# Altium schematic sheet Main.SchDoc
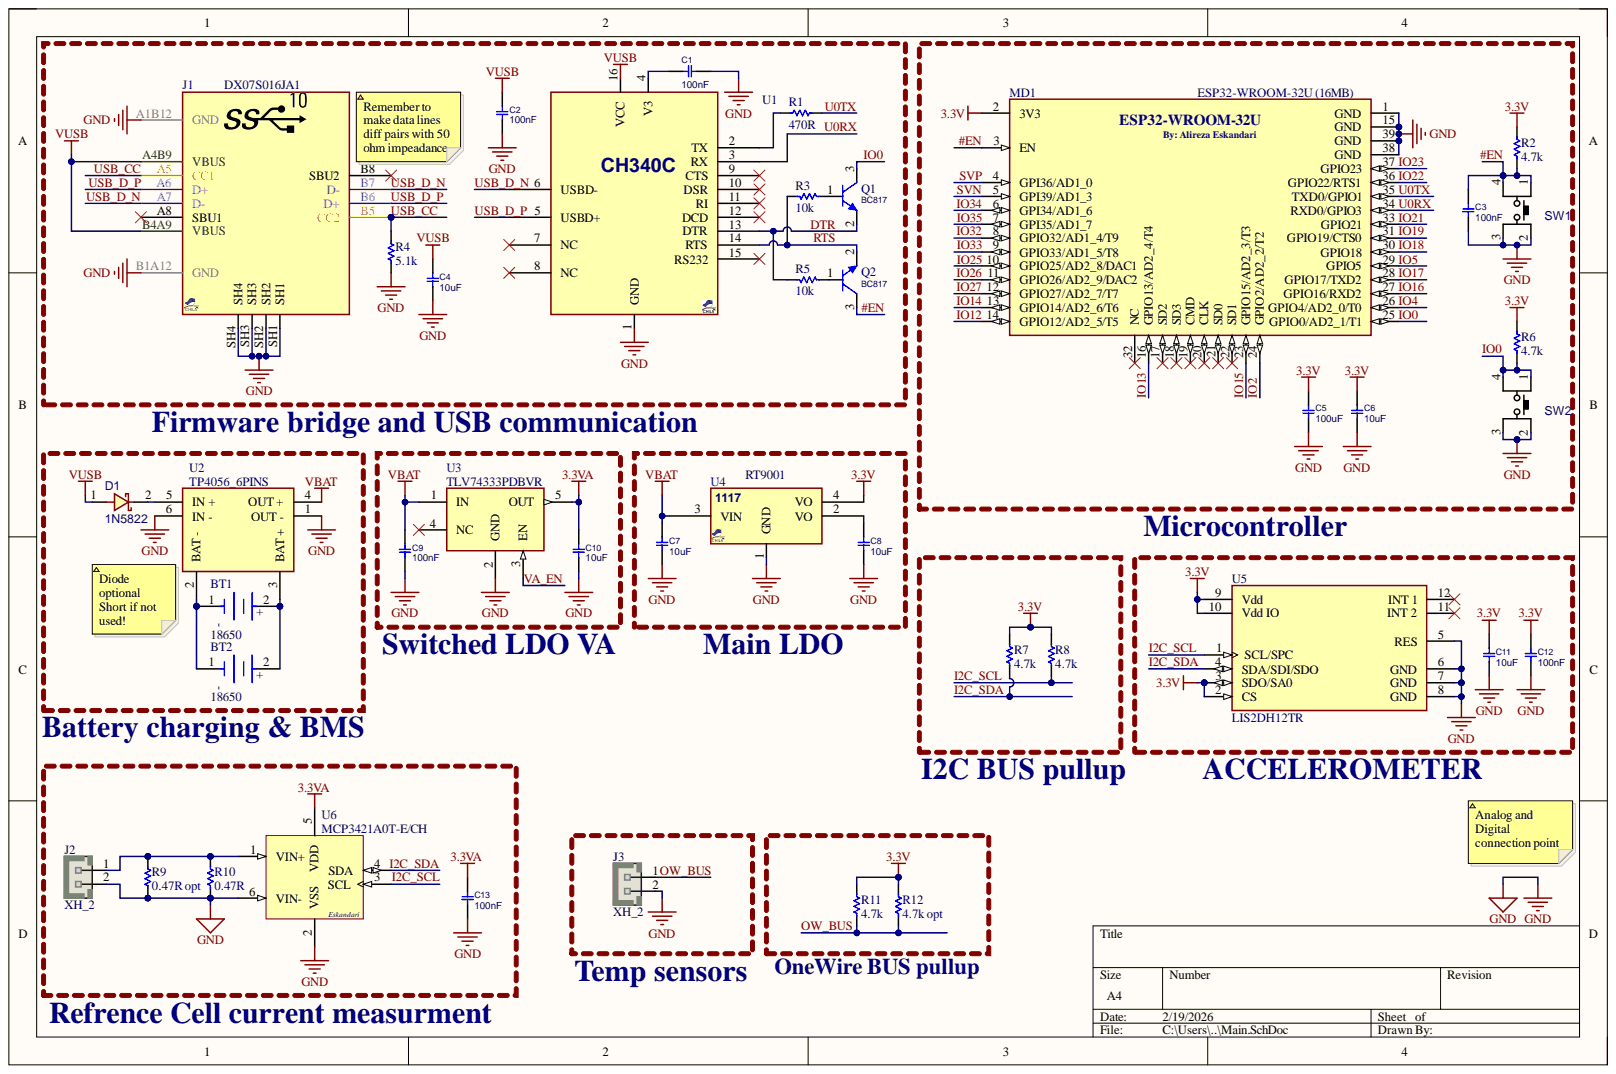
[diagram p0: Main.SchDoc]

## Parts
BT1  18650  [FP-1042P-MFG]
BT2  18650  [FP-1042P-MFG]
C1  100nF  [C0805]
C2  100nF  [C0805]
C3  100nF  [C0805]
C4  10uF  [C0805]
C5  100uF  [C0805]
C6  10uF  [C0805]
C7  10uF  [C0805]
C8  10uF  [C0805]
C9  100nF  [C0805]
C10  10uF  [C0805]
C11  10uF  [C0805]
C12  100nF  [C0805]
C13  100nF  [C0805]
D1  1N5822  [D_SMA]
J1  DX07S016JA1  [USBC_SMD_16_DX07S016JA1]
J2  XH_2  [XH_2]
J3  XH_2  [XH_2]
MD1  ESP32-WROOM-32U (16MB)  [FP-ESP32-WROOM-32U_16MB-MFG]
Q1  BC817  [SOT23_3]
Q2  BC817  [SOT23_3]
R1  470R  [RES0805]
R2  4.7k  [RES0805]
R3  10k  [RES0805]
R4  5.1k  [RES0805]
R5  10k  [RES0805]
R6  4.7k  [RES0805]
R7  4.7k  [RES0805]
R8  4.7k  [RES0805]
R9  0.47R opt  [RES0805]
R10  0.47R  [RES0805]
R11  4.7k  [RES0805]
R12  4.7k opt  [RES0805]
SW1  PUSHBUTTON  [SWPBS_SPDT_5X5X1]  (value not drawn)
SW2  PUSHBUTTON  [SWPBS_SPDT_5X5X1]  (value not drawn)
U1  CH340C  [SO_16]
U2  TP4056_6PINS  [TP4056_6PINs]
U3  TLV74333PDBVR  [FP-DBV0005A-MFG]
U4  RT9001  PARAM2: 3.3V  [SOT223_4]
U5  LIS2DH12TR  [FP-LGA-12-8365767-MFG]
U6  MCP3421A0T-E/CH  [SOT-23-CH6_L]

## Nets
3.3V: C5.2 C6.2 C11.2 C12.1 MD1.2(3V3) R2.2 R6.2 R7.2 R8.2 R11.2 R12.2 U4.4(VO) U5.2(CS) U5.3(SDO/SA0) U5.9(Vdd) U5.10(Vdd_IO)
3.3VA: C10.2 C13.2 U3.5(OUT) U6.5(VDD)
GND: C1.1 C2.1 C3.1 C4.1 C5.1 C6.1 C7.1 C8.1 C9.1 C10.1 C11.1 C12.2 C13.1 J1.A1B12(GND) J1.B1A12(GND) J1.SH1 J1.SH2 J1.SH3 J1.SH4 J2.2 J3.2 MD1.1(GND) MD1.15(GND) MD1.38(GND) MD1.39(GND) R4.1 R9.1 R10.1 SW1.2 SW1.3 SW2.2 SW2.3 U1.1(GND) U2.1(OUT_-) U2.6(IN_-) U3.2(GND) U4.1(GND) U5.5(RES) U5.6(GND) U5.7(GND) U5.8(GND) U6.2(VSS) U6.6(VIN-)
VBAT: C7.2 C9.2 U2.4(OUT_+) U3.1(IN) U4.3(VIN)
VUSB: C2.2 C4.2 D1.1 J1.A4B9(VBUS) J1.B4A9(VBUS) U1.16(VCC)
#EN: C3.2 MD1.3(EN) Q2.3 R2.1 SW1.1 SW1.4
DTR: Q1.2 R5.2 U1.13(DTR)
I2C_SCL: R8.1 U5.1(SCL/SPC) U6.3(SCL)
I2C_SDA: R7.1 U5.4(SDA/SDI/SDO) U6.4(SDA)
IO0: MD1.25(GPIO0/AD2_1/T1) Q1.3 R6.1 SW2.1 SW2.4
IO2: MD1.24(GPIO2/AD2_2/T2)
IO4: MD1.26(GPIO4/AD2_0/T0)
IO5: MD1.29(GPIO5)
IO12: MD1.14(GPIO12/AD2_5/T5)
IO13: MD1.16(GPIO13/AD2_4/T4)
IO14: MD1.13(GPIO14/AD2_6/T6)
IO15: MD1.23(GPIO15/AD2_3/T3)
IO16: MD1.27(GPIO16/RXD2)
IO17: MD1.28(GPIO17/TXD2)
IO18: MD1.30(GPIO18)
IO19: MD1.31(GPIO19/CTS0)
IO21: MD1.33(GPIO21)
IO22: MD1.36(GPIO22/RTS1)
IO23: MD1.37(GPIO23)
IO25: MD1.10(GPIO25/AD2_8/DAC1)
IO26: MD1.11(GPIO26/AD2_9/DAC2)
IO27: MD1.12(GPIO27/AD2_7/T7)
IO32: MD1.8(GPIO32/AD1_4/T9)
IO33: MD1.9(GPIO33/AD1_5/T8)
IO34: MD1.6(GPI34/AD1_6)
IO35: MD1.7(GPI35/AD1_7)
OW_BUS: J3.1 R11.1 R12.1
RTS: Q2.2 R3.2 U1.14(RTS)
SVN: MD1.5(GPI39/AD1_3)
SVP: MD1.4(GPI36/AD1_0)
U0RX: MD1.34(RXD0/GPIO3) U1.3(RX)
U0TX: MD1.35(TXD0/GPIO1) R1.1
USB_CC: J1.A5(CC1) J1.B5(CC2) R4.2
USB_D_N: J1.A7(D-) J1.B7(D-) U1.6(USBD-)
USB_D_P: J1.A6(D+) J1.B6(D+) U1.5(USBD+)
VA_EN: U3.3(EN)
NetBT1_1: BT1.1 BT2.1 U2.2(BAT_-)
NetBT1_2: BT1.2 BT2.2 U2.3(BAT_+)
NetC1_2: C1.2 U1.4(V3)
NetC8_2: C8.2 U4.2(VO)
NetD1_2: D1.2 U2.5(IN_+)
NetJ2_1: J2.1 R9.2 R10.2 U6.1(VIN+)
NetQ1_1: Q1.1 R3.1
NetQ2_1: Q2.1 R5.1
NetR1_2: R1.2 U1.2(TX)

Not connected: J1 A8(SBU1) B8(SBU2); MD1 17(SD2) 18(SD3) 19(CMD) 20(CLK) 21(SD0) 22(SD1) 32(NC); U1 7(NC) 8(NC) 9(CTS) 10(DSR) 11(RI) 12(DCD) 15(RS232); U3 4(NC); U5 11(INT_2) 12(INT_1)

## Library data of the parts
BT1 BT2 = BATTERY-HOLDER-18650: BATTERY HOLDER 18650 SMD TAB; Number of Cells: 1; Component Kind: Standard; Lead Free: Lead Free; Max Operating Temperature: 145°C; Min Operating Temperature: -50°C; Mount: Surface Mount; Package Quantity: 200; Packaging: Tray; Termination: SMD/SMT; Library Name: alib.IntLib
C1-C13 = CAP_SMD_MLCC_0805: CAP, MLCC; MANF_P#: MANF_PART#; PARAM: -, -%; PARAM2: -V; ASSEMBLY: ASSEMBLY; Component Kind: Standard; Library Name: passive_smd.SchLib; MANF: -; PRICE: 100= 1$
  C1-C3 C9 C12 C13: VALUE: 100nF
  C4 C6-C8 C10 C11: VALUE: 10uF
  C5: VALUE: 100uF
D1 = DIODE_SMD_SCHOTTKY_1N5822: DIODE, SCHOTTKY, 40V, 3A; DESC: DESCRIPTION; MANF: DIODES; ASSEMBLY: ASSEMBLY; Component Kind: Standard; Library Name: diode.SchLib; MANF_P#: 1N5822; PARAM: 40V; PARAM2: 3A; PRICE: PRICE; VALUE: 1N5822
J1 = CONN_USBC_SMD_16_DX07S016JA1: CONN, USB, Type C, USB 2.0, Right-Angle, SMD; MANF: JAE; MANF_P#: DX07S016JA1R1500; PARAM: 5A; Component Kind: Standard; Library Name: conn_usbc.SchLib; Price: 1.5$; Value: DX07S016JA1
J2 J3 = XH_2: PW10-x-M, JST-BxB-XH-A pitch: 2.5mm, 3A/250v; MANF: JST; MANF_P#: B2B-XH-A; PARAM: 3.0A; PARAM2: 250v; Component Kind: Standard; Library Name: conn_wire2board.SchLib; VALUE: XH_2
MD1 = ESP32-WROOM-32U: RX TXRX MODULE WIFI U.FL SMD; Data Rate: 150Mbps; Frequency: 2.5GHz; Interface: I2C; Memory Size: 536KB; Sensitivity: -98dBm; Case/Package: SMD/SMT; Component Kind: Standard; Max Operating Temperature: 85°C; Min Operating Temperature: -40°C; Mount: Surface Mount; Packaging: Tape and Reel; Library Name: alib.IntLib
Q1 Q2 = NPN_BC817_SOT23: BJT, NPN, 45v, 0.5A, SMD, SOT23; MANF: NXP, ON; PARAM: 0.5A, Hfe100~600; PARAM2: 45v, 250mW; Component Kind: Standard; Library Name: transistor_bjt.SchLib; MANF_P#: BC817; Simulation: NS3904; VALUE: BC817
R1-R12 = RES SMD PACKAGE: TOTAL SMD RESISTOR PACK; Designer: Alireza Esknadari; ll: RES SMD PAKAGES; Component Kind: Standard; Library Name: alib.IntLib
SW1 SW2 = SWPBS_SPDT_5X5PTS: SWITCH, PUSH BUTTON, TACTILE, SMD, SPDT, 5X5MM; DESC: DESCRIPTION; MANF: CHINA; MANF_P#: PTS526SM15SMTR2LFS; PARAM: 50mA; PARAM2: 500kCycle; ASSEMBLY: ASSEMBLY; Component Kind: Standard; Library Name: switch_tact_PUSHB.SchLib; PRICE: -; VALUE: PUSHBUTTON
U1 = CH340C_SOP16: USB-UART; Component Kind: Standard; MANF_P#: CH340C; PACKAGE: SOP-16; VALUE: CH340C; Library Name: usbic.SchLib
U2 = TP4056_6PINS: MODULE BATTERY CHARGER 6 PINS; Component Kind: Standard; Library Name: alib.IntLib
U3 = TLV74333PDBVR: IC REG LINEAR 3.3V 300MA SOT23-5; Manufacturer: Texas Instruments; Accuracy Percentage: 1%; Dropout Voltage: 125mV; Max Junction Temp: +125°C; Max Supply Voltage: 5.5V; Min Supply Voltage: 1.4V; Nominal Supply Current: 34uA; Number Of Outputs: 1; Output Type: Fixed; Output Voltage: 1-3.3V; Standoff Height: 0mm; Automotive: No; Category: IC; Component Kind: Standard; ComponentLink2Description: Datasheet; ComponentLink2URL: http://www.ti.com/lit/ds/symlink/tlv743p.pdf; Device Class L1: Integrated Circuits (ICs); Device Class L2: Power Management ICs; Device Class L3: Voltage Regulators - Linear; Digikey Description: IC REG LINEAR 3.3V 300MA SOT23-5; Height: 1.45mm; Lead Free: Yes; Manufacturer Part Number: TLV74333PDBVR; Mouser Description: 300mA Low-Dropout (LDO) Regulator 5-SOT-23 -40 to 125; Octopart: https://octopart.com/tlv74333pdbvr-texas+instruments-84791760; Output Current: 300mA; Package: DBV0005A; RoHS: TRUE; Temperature: -40°C to +125°C; Temperature Range High: +125°C; Temperature Range Low: -40°C; Library Name: alib.IntLib
U4 = VREG_1117_SOT223: REG, Linear, LDO1V, 0.6A, SOT-223; MANF: TI, ON, ST; Component Kind: Standard; Library Name: pwr_reg_linear_1117.SchLib; MANF_P#: RT9001; PARAM: LDO1V, 0.6A; VALUE: RT9001
U5 = LIS2DH12TR: ACCEL 2-16G I2C/SPI 12LGA; Axis: X, Y, Z; Bandwidth: 672Hz; Interface: I2C; Max Supply Voltage: 3.6V; Min Supply Voltage: 1.71V; Output Type: SPI; Case/Package: LGA; Component Kind: Standard; Contact Plating: Gold; Height: 1mm; Lead Free: Lead Free; Length: 2.15mm; Max Operating Temperature: 85°C; Min Operating Temperature: -40°C; Mount: Surface Mount; Number of Pins: 12; Packaging: Cut Tape; Radiation Hardening: No; REACH SVHC: No SVHC; Width: 2.15mm; Library Name: alib.IntLib
U6 = MCP3421: 18-Bit Analog-to-Digital Converter with I2C Interface and On-Board Reference, 6-Pin SOT-23, Extended Temperature, Tape and Reel; Code_IPC: SOT26A-6; Component Kind: Standard; ComponentLink1Description: Manufacturer URL; ComponentLink1URL: http://www.microchip.com/; ComponentLink2Description: Datasheet; ComponentLink2URL: http://ww1.microchip.com/downloads/en/DeviceDoc/22003e.pdf; ComponentLink3Description: Package Specification; ComponentLink3URL: http://www.microchip.com/stellent/groups/techpub_sg/documents/packagingspec/en012702.pdf; DatasheetVersion: revE, Aug-2009; PackageDescription: 6-Lead Plastic Small Outline Transistor (CH) [SOT-23]; PackageReference: SOT-23-CH6; PackageVersion: revBB, Aug-2009; RevisionNote: URLs added.; Library Name: alib.IntLib
